# Altium schematic sheet Power.SchDoc
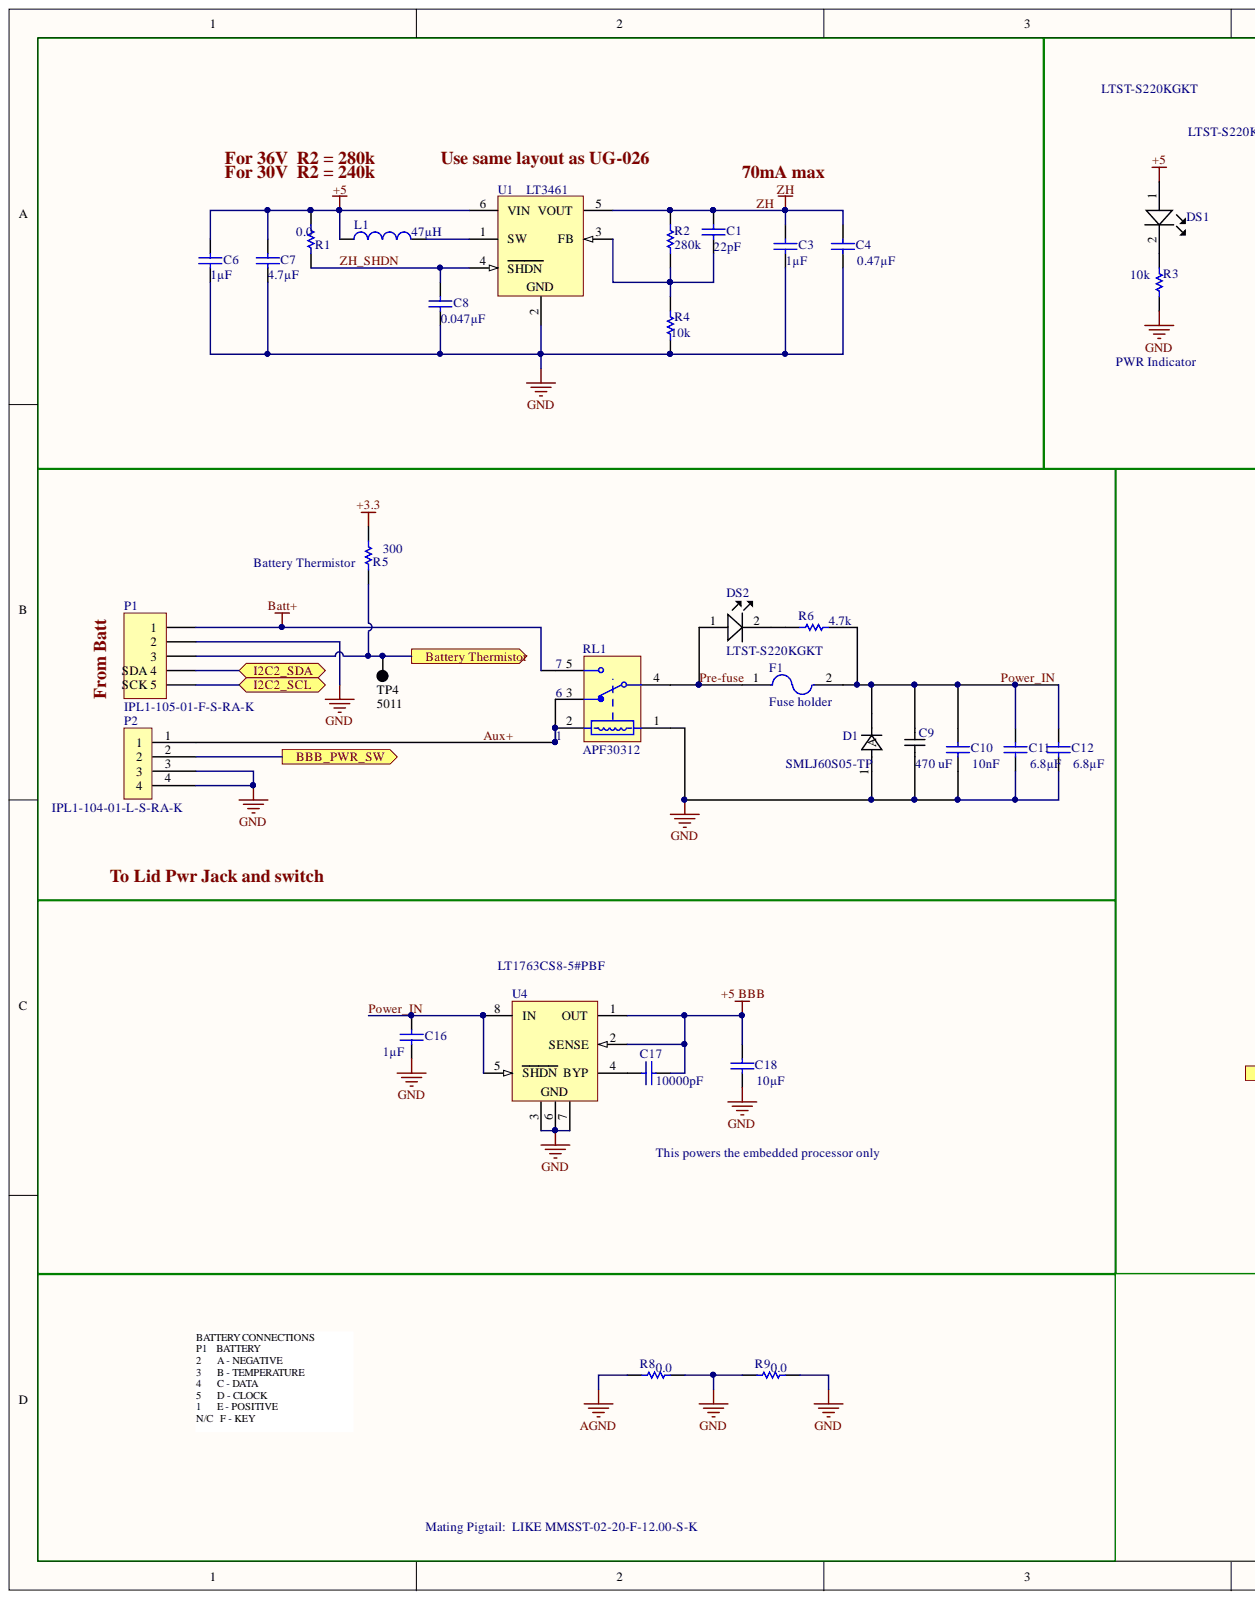
[diagram p0: Power.SchDoc, left]
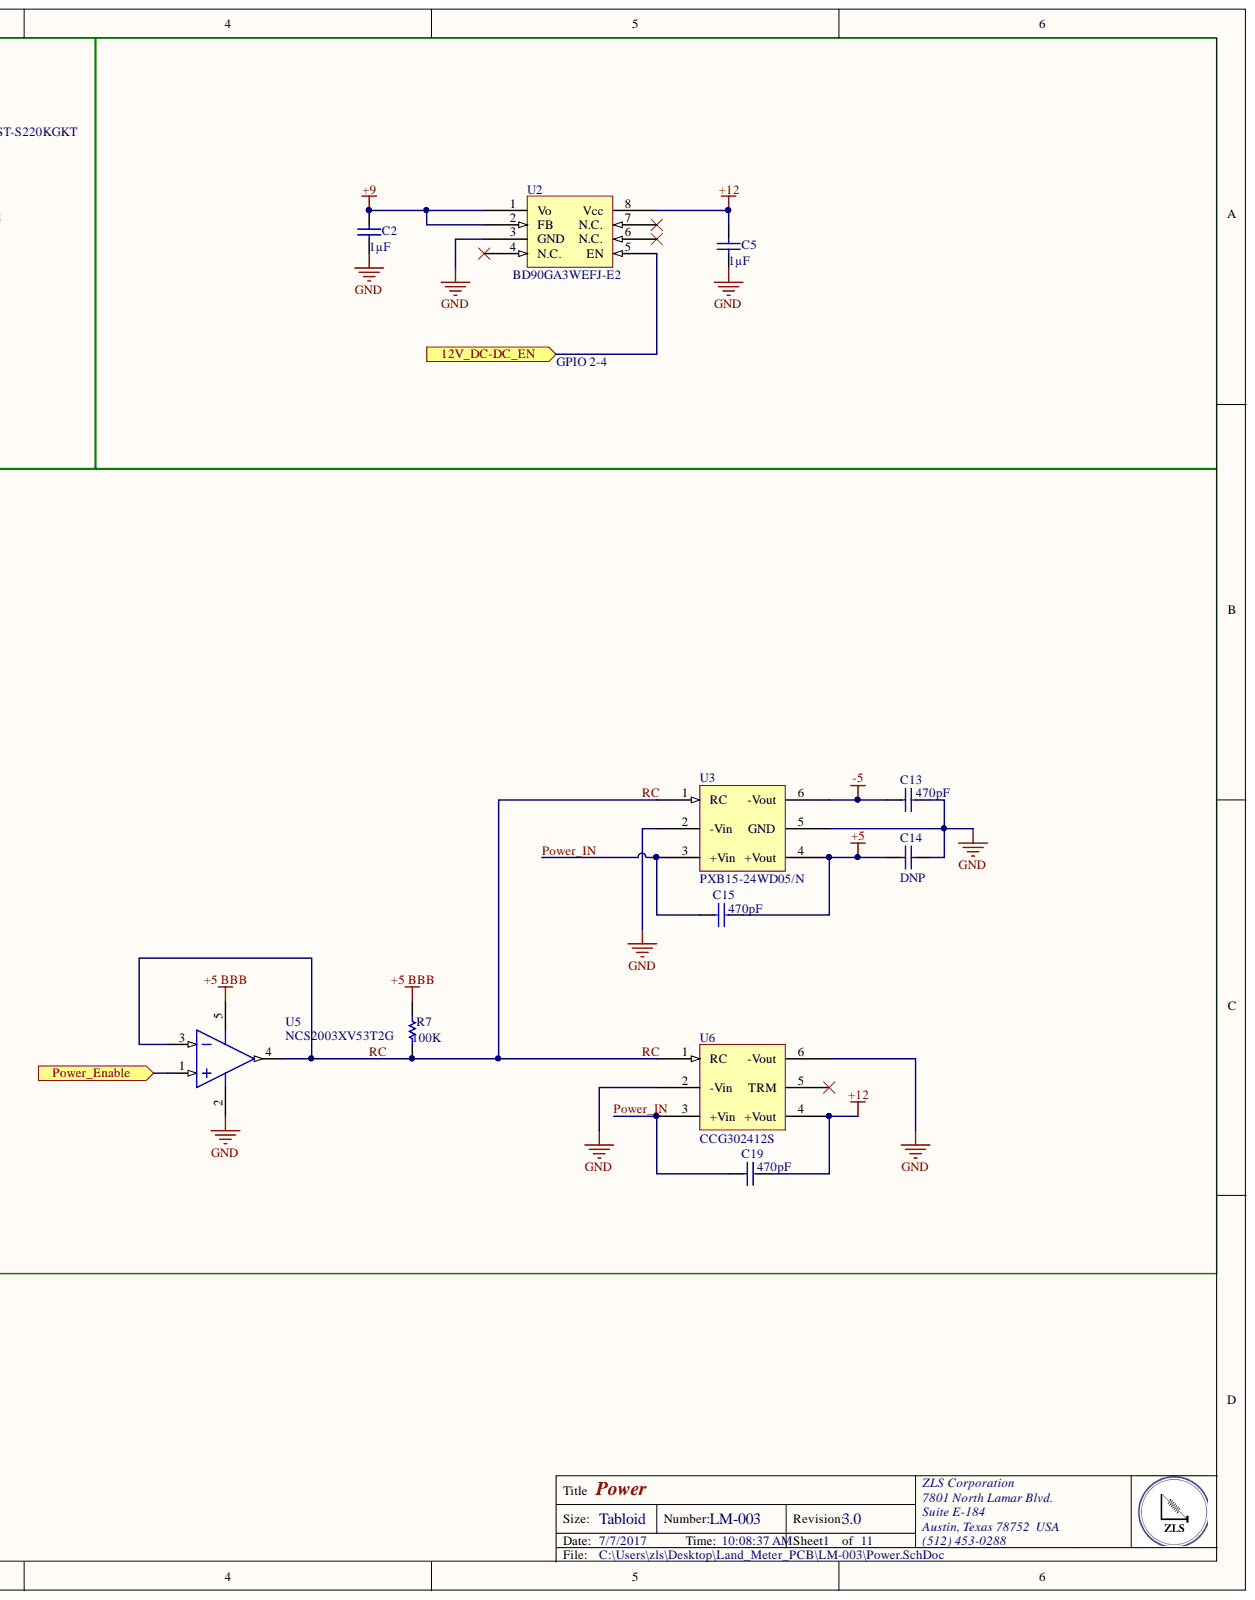
[diagram p1: Power.SchDoc, right]

## Parts
C1  Capacitance: 22pF  [CAPC0603(1608)100_N]  (hidden comment: CAP CER 22PF 50V X7R 0603)
C2  Capacitance: 1µF  [CAPC1206(3216)130_N]  (hidden comment: CAP CER 1UF 50V X7R 1210)
C3  Capacitance: 1µF  [CAPC1210(3225)100_N]  (hidden comment: CAP CER 1UF 50V X7R 1210)
C4  Capacitance: 0.47µF  [CAPC1206(3216)190_N]  (hidden comment: CAP CER 0.47UF 50V X7R 1206)
C5  Capacitance: 1µF  [CAPC1206(3216)130_N]  (hidden comment: CAP CER 1UF 50V X7R 1210)
C6  Capacitance: 1µF  [CAPC0805(2012)145_N]  (hidden comment: CAP CER 1UF 16V X7R 0805)
C7  Capacitance: 4.7µF  [CAPC1206(3216)130_N]  (hidden comment: CAP CER 4.7UF 16V X7R 1206)
C8  Capacitance: 0.047µF  [CAPC0805(2012)145_N]  (hidden comment: CAP CER 0.047UF 16V X7R 0603)
C9  Aluminum Electrolytic Capacitors - Leaded 16V 470uF 20%  [RAD-0.1]  (value not drawn)
C10  Capacitance: 10nF  [CAPC0805(2012)145_N]  (hidden comment: CAP CER 10000PF 50V X7R 0805)
C11  Capacitance: 6.8µF  [CAPC1210(3225)100_L]  (hidden comment: CAP CER 6.8UF 50V X5R 1206)
C12  Capacitance: 6.8µF  [CAPC1210(3225)100_L]  (hidden comment: CAP CER 6.8UF 50V X5R 1206)
C13  Capacitance: 470pF  [CAPC1812(4532)230_M]  (hidden comment: CAP CER 470PF 3KV X7R 1812)
C14  DNP  [CAPC1812(4532)230_M]
C15  Capacitance: 470pF  [CAPC1812(4532)230_M]  (hidden comment: CAP CER 470PF 3KV X7R 1812)
C16  Capacitance: 1µF  [CAPC1210(3225)100_L]  (hidden comment: CAP CER 1UF 50V X7R 1210)
C17  Capacitance: 10000pF  [CAPC0805(2012)145_N]  (hidden comment: CAP CER 10000PF 25V X7R 0805)
C18  Capacitance: 10µF  [CAPC0805(2012)145_N]  (hidden comment: CAP CER 10UF 10V X7R 0805)
C19  Capacitance: 470pF  [CAPC1812(4532)230_M]  (hidden comment: CAP CER 470PF 3KV X7R 1812)
D1  Manufacturer Part Number: SMLJ60S05-TP  [DO-214AB]  (hidden comment: DIODE GEN PURP 50V 6A DO214AB)
DS1  Lite-On LTST-S220KGKT Green LED, 571 nm 2012 (0805) Side View, Rectangle Lens SMD package  [0805C_L]  (value not drawn)
DS2  Manufacturer Part Number: LTST-S220KGKT  [0805C_L]  (hidden comment: Lite-On LTST-S220KGKT Green LED, 571 nm 2012 (0805) Side View, Rectangle Lens SMD package)
F1  Fuse holder  [01530007Z]
L1  Inductance: 47µH  [0402-A]  (hidden comment: FIXED IND 47UH 170MA 1.3 OHM SMD)
P1  IPL1-105-01-F-S-RA-K  [IPL1-105-1]
P2  IPL1-104-01-L-S-RA-K  [IPL1-104-01]
R1  Resistance (Ohms): 0.0  [RESC0805(2012)_M]  (hidden comment: RES SMD 0.0 OHM JUMPER 1/8W 0805)
R2  Resistance (Ohms): 280k  [RESC0805(2012)_M]  (hidden comment: RES SMD 280K OHM 1% 1/8W 0805)
R3  Resistance (Ohms): 10k  [RESC0805(2012)_M]  (hidden comment: RES SMD 10K OHM 1% 1/8W 0805)
R4  Resistance (Ohms): 10k  [RESC0805(2012)_M]  (hidden comment: RES SMD 10K OHM 1% 1/8W 0805)
R5  300K 5% 0805(2012)  [RESC0805(2012)_M]  (value not drawn)
R6  Resistance (Ohms): 4.7k  [RESC0805(2012)_M]  (hidden comment: RES SMD 4.7K OHM 1% 1/8W 0805)
R7  Resistance: 100K  [RESC0805(2012)_M]  (hidden comment: RES SMD 100K OHM 1% 1/8W 0805)
R8  Resistance (Ohms): 0.0  [RESC0805(2012)_M]  (hidden comment: RES SMD 0.0 OHM JUMPER 1/8W 0805)
R9  Resistance (Ohms): 0.0  [RESC0805(2012)_M]  (hidden comment: RES SMD 0.0 OHM JUMPER 1/8W 0805)
RL1  APF30312  [PNSC-PF-5-2800x500x1500_V]
TP4  5011  [KSTN-5011_V]
U1  LT3461  [LT-S6-6-TSOT-23_N]
U2  BD90GA3WEFJ-E2  [TSOP127P600X100-8N]
U3  PXB15-24WD05/N  [PXB15-24WD05/N]
U4  LT1763CS8-5#PBF  [LT-S8-8_M]
U5  NCS2003XV53T2G  [ONSC-SOT-553-5-463B_V]
U6  CCG302412S  [CCG30]

## Ports
12V_DC-DC_EN, Battery Thermistor, BBB_PWR_SW, I2C2_SCL, I2C2_SDA, Power_Enable

## Nets
+3.3: R5.2
+5: C6.2 C7.2 C14.1 C15.2 DS1.1 L1.1 R1.1 U1.6(VIN) U3.4(+Vout)
+5 BBB: C17.1 C18.2 R7.1 U4.1(OUT) U4.2(SENSE) U5.5
+9: C2.2 U2.1(Vo) U2.2(FB)
+12: C5.2 C19.2 U2.8(Vcc) U6.4(+Vout)
-5: C13.1 U3.6(-Vout)
AGND: R8.2
Batt+: P1.1 RL1.5
GND: C2.1 C3.1 C4.1 C5.1 C6.1 C7.1 C8.1 C9.2 C10.1 C11.1 C12.1 C13.2 C14.2 C16.1 C18.1 D1.1 P1.2 P2.3 P2.4 R3.1 R4.2 R8.1 R9.1 R9.2 RL1.1 U1.2 U2.3(GND) U3.2(-Vin) U3.5(GND) U4.3 U4.6 U4.7 U5.2 U6.2(-Vin) U6.6(-Vout)
12V_DC-DC_EN: U2.5(EN) ; port 12V_DC-DC_EN
Aux+: P2.1 RL1.2 RL1.3
Battery Thermistor: P1.3 R5.1 TP4.1 ; port Battery Thermistor
BBB_PWR_SW: P2.2 ; port BBB_PWR_SW
I2C2_SCL: P1.5(SCK_5) ; port I2C2_SCL
I2C2_SDA: P1.4(SDA_4) ; port I2C2_SDA
Power_Enable: U5.1 ; port Power_Enable
Power_IN: C9.1 C10.2 C11.2 C12.2 C15.1 C16.2 C19.1 D1.2 F1.2 R6.1 U3.3(+Vin) U4.5(SHDN) U4.8(IN) U6.3(+Vin)
Pre-fuse: DS2.1 F1.1 RL1.4
RC: R7.2 U3.1(RC) U5.3 U5.4 U6.1(RC)
ZH: C1.2 C3.2 C4.2 R2.1 U1.5(VOUT)
ZH_SHDN: C8.2 R1.2 U1.4(SHDN)
NetC1_1: C1.1 R2.2 R4.1 U1.3(FB)
NetC17_2: C17.2 U4.4(BYP)
NetDS1_2: DS1.2 R3.2
NetDS2_2: DS2.2 R6.2
NetL1_2: L1.2 U1.1(SW)

Not connected: U2 4(N.C.) 6(N.C.) 7(N.C.); U6 5(TRM)

## Library data of the parts
C1 = CMP-1035-00578-1: Manufacturer: AVX Corporation; Manufacturer Part Number: 06035C220JAT2A; Tolerance: ±5%; Applications: General Purpose; Category: Capacitors; Component Kind: Standard; ComponentLink1Description: http://datasheets.avx.com/X7RDielectric.pdf; ComponentLink1URL: http://datasheets.avx.com/X7RDielectric.pdf; Description: CAP CER 22PF 50V X7R 0603; Features: -; Height - Seated (Max): -; Lead Spacing: -; Lead Style: -; Library Name: Altium Content Vault; Mounting Type: Surface Mount, MLCC; Operating Temperature: -55°C ~ 125°C; Package / Case: 0603 (1608 Metric); Packaging: Digi-Reel®; Part Status: Active; Pricing 1: 1=0.2, 10=0.136, 100=0.0688, 1000=0.04166 (USD); Ratings: -; RoHS: RoHS Compliant; Size / Dimension: 0.063" L x 0.032" W (1.60mm x 0.81mm); Stock 1: 72942; Supplier 1: Digi-Key; Supplier Part Number 1: 478-6204-6-ND; Temperature Coefficient: X7R; Thickness (Max): 0.035" (0.90mm); Voltage - Rated: 50V
C2 C5 = CMP-1037-04913-1: Manufacturer: Murata Electronics North America; Manufacturer Part Number: GRM32RR71H105KA01L; Tolerance: ±10%; Applications: General Purpose; Category: Capacitors; Component Kind: Standard; ComponentLink1Description: http://search.murata.co.jp/Ceramy/image/img/A01X/G101/ENG/GRM32RR71H105KA01-01.pdf; ComponentLink1URL: http://search.murata.co.jp/Ceramy/image/img/A01X/G101/ENG/GRM32RR71H105KA01-01.pdf; Description: CAP CER 1UF 50V X7R 1210; Features: -; Height - Seated (Max): -; Lead Spacing: -; Lead Style: -; Library Name: Altium Content Vault; Mounting Type: Surface Mount, MLCC; Operating Temperature: -55°C ~ 125°C; Package / Case: 1210 (3225 Metric); Packaging: Digi-Reel®; Part Status: Active; Pricing 1: 1=0.35, 10=0.242, 100=0.1449, 500=0.10868 (USD); Ratings: -; RoHS: RoHS Compliant; Size / Dimension: 0.126" L x 0.098" W (3.20mm x 2.50mm); Stock 1: 66890; Supplier 1: Digi-Key; Supplier Part Number 1: 490-1863-6-ND; Temperature Coefficient: X7R; Thickness (Max): 0.079" (2.00mm); Voltage - Rated: 50V
C3 = CMP-1038-01123-1: Manufacturer: Taiyo Yuden; Manufacturer Part Number: UMK325B7105KH-T; Tolerance: ±10%; Applications: General Purpose; Category: Capacitors; Component Kind: Standard; ComponentLink1Description: http://www.yuden.co.jp/productdata/catalog/en/mlcc_all_e.pdf; ComponentLink1URL: http://www.yuden.co.jp/productdata/catalog/en/mlcc_all_e.pdf; Description: CAP CER 1UF 50V X7R 1210; Features: -; Height - Seated (Max): -; Lead Spacing: -; Lead Style: -; Library Name: Altium Content Vault; Mounting Type: Surface Mount, MLCC; Operating Temperature: -55°C ~ 125°C; Package / Case: 1210 (3225 Metric); Packaging: Cut Tape (CT); Part Status: Active; Pricing 1: 1=0.36, 10=0.247, 100=0.1398, 500=0.0987, 1000=0.08637 (USD); Ratings: -; RoHS: RoHS Compliant; Size / Dimension: 0.126" L x 0.098" W (3.20mm x 2.50mm); Stock 1: 23864; Supplier 1: Digi-Key; Supplier Part Number 1: 587-1366-1-ND; Temperature Coefficient: X7R; Thickness (Max): 0.063" (1.60mm); Voltage - Rated: 50V
C4 = CMP-1037-04570-1: Manufacturer: Taiyo Yuden; Manufacturer Part Number: UMK316B7474KL-T; Tolerance: ±10%; Applications: General Purpose; Category: Capacitors; Component Kind: Standard; ComponentLink1Description: http://www.yuden.co.jp/productdata/catalog/en/mlcc_all_e.pdf; ComponentLink1URL: http://www.yuden.co.jp/productdata/catalog/en/mlcc_all_e.pdf; Description: CAP CER 0.47UF 50V X7R 1206; Features: -; Height - Seated (Max): -; Lead Spacing: -; Lead Style: -; Library Name: Altium Content Vault; Mounting Type: Surface Mount, MLCC; Operating Temperature: -55°C ~ 125°C; Package / Case: 1206 (3216 Metric); Packaging: Cut Tape (CT); Part Status: Active; Pricing 1: 1=0.3, 10=0.203, 100=0.115, 500=0.08114, 1000=0.071 (USD); Ratings: -; RoHS: RoHS Compliant; Size / Dimension: 0.126" L x 0.063" W (3.20mm x 1.60mm); Stock 1: 8658; Supplier 1: Digi-Key; Supplier Part Number 1: 587-1325-1-ND; Temperature Coefficient: X7R; Thickness (Max): 0.071" (1.80mm); Voltage - Rated: 50V
C6 = CMP-1036-04795-1: Manufacturer: Taiyo Yuden; Manufacturer Part Number: EMK212B7105KG-T; Tolerance: ±10%; Applications: General Purpose; Category: Capacitors; Component Kind: Standard; ComponentLink1Description: http://ds.yuden.co.jp/TYCOMPAS/ut/detail.do?productNo=EMK212B7105KG-T&fileName=EMK212B7105KG-T_SS&mode=specSheetDownload; ComponentLink1URL: http://ds.yuden.co.jp/TYCOMPAS/ut/detail.do?productNo=EMK212B7105KG-T&fileName=EMK212B7105KG-T_SS&mode=specSheetDownload; Description: CAP CER 1UF 16V X7R 0805; Features: -; Height - Seated (Max): -; Lead Spacing: -; Lead Style: -; Library Name: Altium Content Vault; Mounting Type: Surface Mount, MLCC; Operating Temperature: -55°C ~ 125°C; Package / Case: 0805 (2012 Metric); Packaging: Cut Tape (CT); Part Status: Active; Pricing 1: 1=0.13, 10=0.089, 100=0.042, 1000=0.02439 (USD); Ratings: -; RoHS: RoHS Compliant; Size / Dimension: 0.079" L x 0.049" W (2.00mm x 1.25mm); Stock 1: 41495; Supplier 1: Digi-Key; Supplier Part Number 1: 587-1283-1-ND; Temperature Coefficient: X7R; Thickness (Max): 0.053" (1.35mm); Voltage - Rated: 16V
C7 = CMP-1037-04913-1: Manufacturer: Taiyo Yuden; Manufacturer Part Number: EMK316B7475KL-T; Tolerance: ±10%; Applications: General Purpose; Category: Capacitors; Component Kind: Standard; ComponentLink1Description: http://ds.yuden.co.jp/TYCOMPAS/ut/detail.do?productNo=EMK316B7475KL-T&fileName=EMK316B7475KL-T_SS&mode=specSheetDownload; ComponentLink1URL: http://ds.yuden.co.jp/TYCOMPAS/ut/detail.do?productNo=EMK316B7475KL-T&fileName=EMK316B7475KL-T_SS&mode=specSheetDownload; Description: CAP CER 4.7UF 16V X7R 1206; Features: -; Height - Seated (Max): -; Lead Spacing: -; Lead Style: -; Library Name: Altium Content Vault; Mounting Type: Surface Mount, MLCC; Operating Temperature: -55°C ~ 125°C; Package / Case: 1206 (3216 Metric); Packaging: Digi-Reel®; Part Status: Active; Pricing 1: 1=0.25, 10=0.17, 100=0.0965, 1000=0.0596 (USD); Ratings: -; RoHS: RoHS Compliant; Size / Dimension: 0.126" L x 0.063" W (3.20mm x 1.60mm); Stock 1: 59490; Supplier 1: Digi-Key; Supplier Part Number 1: 587-1331-6-ND; Temperature Coefficient: X7R; Thickness (Max): 0.071" (1.80mm); Voltage - Rated: 16V
C8 = CMP-1036-04795-1: Manufacturer: Murata Electronics North America; Manufacturer Part Number: GRM188R71C473KA01D; Tolerance: ±10%; Applications: General Purpose; Category: Capacitors; Component Kind: Standard; ComponentLink1Description: http://www.murata.com/~/media/webrenewal/support/library/catalog/products/capacitor/mlcc/c02e.ashx; ComponentLink1URL: http://www.murata.com/~/media/webrenewal/support/library/catalog/products/capacitor/mlcc/c02e.ashx; Description: CAP CER 0.047UF 16V X7R 0603; Features: -; Height - Seated (Max): -; Lead Spacing: -; Lead Style: -; Library Name: Altium Content Vault; Mounting Type: Surface Mount, MLCC; Operating Temperature: -55°C ~ 125°C; Package / Case: 0603 (1608 Metric); Packaging: Digi-Reel®; Part Status: Active; Pricing 1: 1=0.1, 10=0.046, 100=0.0206, 500=0.0147, 1000=0.01155 (USD); Ratings: -; RoHS: RoHS Compliant; Size / Dimension: 0.063" L x 0.031" W (1.60mm x 0.80mm); Stock 1: 26; Supplier 1: Digi-Key; Supplier Part Number 1: 490-1529-6-ND; Temperature Coefficient: X7R; Thickness (Max): 0.035" (0.90mm); Voltage - Rated: 16V
C9 = CAP B: Manufacturer Part Number 1: TEA471M1CBK-0816P; Brand: Lelon; Case Diameter: 8 mm; Case Length: 16 mm; Dissipation Factor DF: 0.17; Load Life: 2000 h; Product Status: Factory Special Order; Ripple Current: 460 mA; Capacitance: 470 uF; Category 1: Aluminum Electrolytic Capacitors - Leaded; Component Kind: Standard; ComponentLink1Description: http://www.mouser.com/catalog/specsheets/ea.pdf; ComponentLink1URL: http://www.mouser.com/catalog/specsheets/ea.pdf; Description: Aluminum Electrolytic Capacitors - Leaded 16V 470uF 20%; Description 1: Aluminum Electrolytic Capacitors - Leaded 16V 470uF 20%; Dimensions: 8 mm Dia. x 16 mm L; Manufacturer 1: Lelon; Maximum Operating Temperature: + 85 C; Minimum Order Quantity: 2000; Product: General Purpose Electrolytic Capacitors; Product Category: Axial Electrolytic Capacitors; Reeling: False; RoHS 1: RoHS Compliant; RoHS - Mouser: Y; Series: TEA; Standard Pack Qty: 500; Stock 1: 0; Supplier 1: Mouser; Supplier Part Number 1: 140-TEA471M1CBK0816P; Termination Style: Axial; Tolerance: 20 %; Voltage Rating DC: 16 V
C10 = CMP-1036-04795-1: Manufacturer: Kemet; Manufacturer Part Number: C0805F103K5RACTU; Dielectric Material: Ceramic; Tolerance: 10%; Case/Package: 0805; Case Code (Imperial): 0805; Case Code (Metric): 2012; Component Kind: Standard; ComponentLink1Description: https://datasheet.ciiva.com/146/docfinderpartnumber-c0805f103k5ractu-146278.pdf; ComponentLink1URL: https://datasheet.ciiva.com/146/docfinderpartnumber-c0805f103k5ractu-146278.pdf; ComponentLink2Description: https://datasheet.ciiva.com/7842/48829-7842407.pdf; ComponentLink2URL: https://datasheet.ciiva.com/7842/48829-7842407.pdf; ComponentLink3Description: https://datasheet.ciiva.com/7842/48829-7842408.pdf; ComponentLink3URL: https://datasheet.ciiva.com/7842/48829-7842408.pdf; Depth: 1.25mm; Description: CAP CER 10000PF 50V X7R 0805; Dielectric: X7R; Height: 0.9mm; Lead Pitch: 0.75mm; Length: 2mm; Library Name: Altium Content Vault; Max Operating Temperature: 125°C; Min Operating Temperature: -55°C; Mount: Surface Mount; Number of Pins: 2; Package Quantity: 4000; Packaging: Cut Tape; Pricing 1: 1=0.29, 10=0.202, 100=0.1146, 500=0.0809, 1000=0.0708 (USD); REACH SVHC: No SVHC; Stock 1: 29640; Supplier 1: Digi-Key; Supplier Part Number 1: 399-5107-6-ND; Termination: SMD/SMT; Thickness: 0.039inch; Voltage: 50V; Voltage Rating: 50V; Voltage Rating (DC): 50V; Width: 1.25mm
C11 C12 = CMP-1038-00632-1: Manufacturer: TDK Corporation; Manufacturer Part Number: C3216X5R1H685K160AB; Tolerance: ±10%; Applications: General Purpose; Category: Capacitors; Component Kind: Standard; ComponentLink1Description: https://product.tdk.com/info/en/catalog/datasheets/mlcc_commercial_general_en.pdf; ComponentLink1URL: https://product.tdk.com/info/en/catalog/datasheets/mlcc_commercial_general_en.pdf; Description: CAP CER 6.8UF 50V X5R 1206; Failure Rate: -; Features: Low ESL; Height - Seated (Max): -; Lead Spacing: -; Lead Style: -; Library Name: Altium Content Vault; Mounting Type: Surface Mount, MLCC; Operating Temperature: -55°C ~ 85°C; Package / Case: 1206 (3216 Metric); Packaging: Digi-Reel®; Part Status: Active; Pricing 1: 1=1.03, 10=0.785, 100=0.5615, 500=0.4347, 1000=0.37433 (USD); Ratings: -; RoHS: RoHS Compliant; Size / Dimension: 0.126" L x 0.063" W (3.20mm x 1.60mm); Stock 1: 29817; Supplier 1: Digi-Key; Supplier Part Number 1: 445-5996-6-ND; Temperature Coefficient: X5R; Thickness (Max): 0.071" (1.80mm); Voltage - Rated: 50V
C13 C15 C19 = CMP-1040-00561-1: Manufacturer: Kemet; Manufacturer Part Number: C1812C471KHRACTU; Tolerance: 10%; Case/Package: 1812; Case Code (Imperial): 1812; Case Code (Metric): 4532; Component Kind: Standard; ComponentLink1Description: http://www.kemet.com/docfinder?Partnumber=C1812C471KHRACTU; ComponentLink1URL: http://www.kemet.com/docfinder?Partnumber=C1812C471KHRACTU; Description: CAP CER 470PF 3KV X7R 1812; Lead Free: Lead Free; Length: 0.177inch; Library Name: Altium Content Vault; Max Operating Temperature: 125°C; Min Operating Temperature: -55°C; Package Quantity: 1; Packaging: Tape and Reel; Pricing 1: 1=0.88, 10=0.666, 100=0.4761 (USD); Stock 1: 2705; Supplier 1: Digi-Key; Supplier Part Number 1: 399-7289-6-ND; Thickness: 0.071inch; Voltage Rating: 3kV; Voltage Rating (DC): 3kV; Width: 0.126inch
C14 = CMP-1040-00561-1: CAP CER 470PF 3KV X7R 1812; Manufacturer: Kemet; Manufacturer Part Number: C1812C471KHRACTU; Tolerance: 10%; Capacitance: 470pF; Case/Package: 1812; Case Code (Imperial): 1812; Case Code (Metric): 4532; Component Kind: Standard; ComponentLink1Description: http://www.kemet.com/docfinder?Partnumber=C1812C471KHRACTU; ComponentLink1URL: http://www.kemet.com/docfinder?Partnumber=C1812C471KHRACTU; Lead Free: Lead Free; Length: 0.177inch; Library Name: Altium Content Vault; Max Operating Temperature: 125°C; Min Operating Temperature: -55°C; Package Quantity: 1; Packaging: Tape and Reel; Pricing 1: 1=0.88, 10=0.666, 100=0.4761 (USD); Stock 1: 2705; Supplier 1: Digi-Key; Supplier Part Number 1: 399-7289-6-ND; Thickness: 0.071inch; Voltage Rating: 3kV; Voltage Rating (DC): 3kV; Width: 0.126inch
C16 = CMP-1038-00632-1: Manufacturer: Murata Electronics North America; Manufacturer Part Number: GRM32RR71H105KA01L; Tolerance: ±10%; Applications: General Purpose; Category: Capacitors; Component Kind: Standard; ComponentLink1Description: http://search.murata.co.jp/Ceramy/image/img/A01X/G101/ENG/GRM32RR71H105KA01-01.pdf; ComponentLink1URL: http://search.murata.co.jp/Ceramy/image/img/A01X/G101/ENG/GRM32RR71H105KA01-01.pdf; Description: CAP CER 1UF 50V X7R 1210; Features: -; Height - Seated (Max): -; Lead Spacing: -; Lead Style: -; Library Name: Altium Content Vault; Mounting Type: Surface Mount, MLCC; Operating Temperature: -55°C ~ 125°C; Package / Case: 1210 (3225 Metric); Packaging: Digi-Reel®; Part Status: Active; Pricing 1: 1=0.35, 10=0.242, 100=0.1449, 500=0.10868 (USD); Ratings: -; RoHS: RoHS Compliant; Size / Dimension: 0.126" L x 0.098" W (3.20mm x 2.50mm); Stock 1: 66890; Supplier 1: Digi-Key; Supplier Part Number 1: 490-1863-6-ND; Temperature Coefficient: X7R; Thickness (Max): 0.079" (2.00mm); Voltage - Rated: 50V
C17 = CMP-1036-04795-1: Manufacturer: Murata Electronics North America; Manufacturer Part Number: GRM216R71E103KA01D; Tolerance: ±10%; Applications: General Purpose; Category: Capacitors; Component Kind: Standard; ComponentLink1Description: http://www.murata.com/~/media/webrenewal/support/library/catalog/products/capacitor/mlcc/c02e.ashx; ComponentLink1URL: http://www.murata.com/~/media/webrenewal/support/library/catalog/products/capacitor/mlcc/c02e.ashx; Description: CAP CER 10000PF 25V X7R 0805; Features: -; Height - Seated (Max): -; Lead Spacing: -; Lead Style: -; Library Name: Altium Content Vault; Mounting Type: Surface Mount, MLCC; Operating Temperature: -55°C ~ 125°C; Package / Case: 0805 (2012 Metric); Packaging: Digi-Reel®; Part Status: Active; Pricing 1: 1=0.11, 10=0.077, 100=0.0343, 500=0.02452, 1000=0.01926 (USD); Ratings: -; RoHS: RoHS Compliant; Size / Dimension: 0.079" L x 0.049" W (2.00mm x 1.25mm); Stock 1: 13127; Supplier 1: Digi-Key; Supplier Part Number 1: 490-12748-6-ND; Temperature Coefficient: X7R; Thickness (Max): 0.028" (0.70mm); Voltage - Rated: 25V
C18 = CMP-1036-04795-1: Manufacturer: Murata Electronics North America; Manufacturer Part Number: GCM21BR71A106KE22L; Tolerance: ±10%; Applications: Automotive; Category: Capacitors; Component Kind: Standard; ComponentLink1Description: http://search.murata.co.jp/Ceramy/image/img/A01X/G101/ENG/GCM21BR71A106KE22-01.pdf; ComponentLink1URL: http://search.murata.co.jp/Ceramy/image/img/A01X/G101/ENG/GCM21BR71A106KE22-01.pdf; Description: CAP CER 10UF 10V X7R 0805; Features: -; Height - Seated (Max): -; Lead Spacing: -; Lead Style: -; Library Name: Altium Content Vault; Mounting Type: Surface Mount, MLCC; Operating Temperature: -55°C ~ 125°C; Package / Case: 0805 (2012 Metric); Packaging: Digi-Reel®; Part Status: Active; Pricing 1: 1=0.49, 10=0.347, 100=0.2282, 500=0.16906, 1000=0.14369 (USD); Ratings: AEC-Q200; RoHS: RoHS Compliant; Size / Dimension: 0.079" L x 0.049" W (2.00mm x 1.25mm); Stock 1: 136726; Supplier 1: Digi-Key; Supplier Part Number 1: 490-10674-6-ND; Temperature Coefficient: X7R; Thickness (Max): 0.055" (1.40mm); Voltage - Rated: 10V
D1 = DIODE A/K A: Manufacturer: Micro Commercial Co; Manufacturer 1: ON Semiconductor; Category 1: Discrete Semiconductor Products; Current - Reverse Leakage @ Vr: 25µA @ 50V; Description 1: DIODE GP 50V 6A MICRODE BUTTON; Diode Type: Standard; Speed: Standard Recovery >500ns, > 200mA (Io); Voltage - Forward (Vf) (Max) @ If: 1V @ 6A; Capacitance @ Vr, F: -; Category: Discrete Semiconductor Products; Component Kind: Standard; ComponentLink1Description: http://www.onsemi.com/pub_link/Collateral/MR750-D.PDF; ComponentLink1URL: http://www.onsemi.com/pub_link/Collateral/MR750-D.PDF; ComponentLink2Description: http://www.mccsemi.com/up_pdf/SMLJ60S05~SMLJ60S10(DO-214AB).pdf; ComponentLink2URL: http://www.mccsemi.com/up_pdf/SMLJ60S05~SMLJ60S10(DO-214AB).pdf; Current - Average Rectified (Io): 6A; Description: DIODE GEN PURP 50V 6A DO214AB; Mounting Type: Surface Mount; Operating Temperature - Junction: -55°C ~ 150°C; Package / Case: DO-214AB, SMC; Packaging: Cut Tape (CT); Part Status: Active; Pricing 1: 1=1.06, 10=0.939, 25=0.848, 100=0.7419, 250=0.65108, 500=0.57536, 1000=0.45423 (USD); RoHS: RoHS Compliant; Stock 1: 6086; Supplier 1: Digi-Key; Supplier Device Package: DO-214AB, (SMC); Supplier Part Number 1: SMLJ60S05-TPMSCT-ND; Voltage - DC Reverse (Vr) (Max): 50V
DS1 DS2 = LED A/K: Manufacturer: Vishay / Lite-On; Dominant Wavelength: 568nm; Forward Current: 20mA; Forward Voltage: 2V; Lens Colour: Colorless; Lens Style: Diffused,Colorless; Lens Transparency: Clear; Luminous Intensity: 35mcd; Number of Elements: 1; Power Dissipation: 75W; Power Rating: 75mW; Viewing Angle: 130°; Wavelength: 574nm; Case/Package: 0805; Case Code (Imperial): 0805; Case Code (Metric): 2012; Colour: Green; Component Kind: Standard; ComponentLink1Description: https://datasheet.ciiva.com/11775/0900766b80dc0b70-11775441.pdf; ComponentLink1URL: https://datasheet.ciiva.com/11775/0900766b80dc0b70-11775441.pdf; ComponentLink2Description: https://datasheet.ciiva.com/2110/s-110-ltst-s220kgkt-2110069.pdf; ComponentLink2URL: https://datasheet.ciiva.com/2110/s-110-ltst-s220kgkt-2110069.pdf; ComponentLink3Description: https://datasheet.ciiva.com/2110/s-110-ltst-s220kgkt-2110070.pdf; ComponentLink3URL: https://datasheet.ciiva.com/2110/s-110-ltst-s220kgkt-2110070.pdf; ComponentLink4Description: https://datasheet.ciiva.com/12400/100-ltst-s220kgkt-59602-12400886.pdf; ComponentLink4URL: https://datasheet.ciiva.com/12400/100-ltst-s220kgkt-59602-12400886.pdf; ComponentLink5Description: https://datasheet.ciiva.com/2110/s-110-ltst-s220kgkt-2110071.pdf; ComponentLink5URL: https://datasheet.ciiva.com/2110/s-110-ltst-s220kgkt-2110071.pdf; ComponentLink6Description: https://datasheet.ciiva.com/16379/ltst-s220kgkt-16379082.pdf; ComponentLink6URL: https://datasheet.ciiva.com/16379/ltst-s220kgkt-16379082.pdf; Depth: 0.6mm; Description: Lite-On LTST-S220KGKT Green LED, 571 nm 2012 (0805) Side View, Rectangle Lens SMD package; Height: 0.6mm; Illumination Colour: Green; Lead Free: Lead Free; Length: 2.1mm; Max Operating Temperature: 85°C; Min Operating Temperature: -55°C; Mount: Surface Mount; Number of LEDs: 1; Number of Pins: 2; Package Quantity: 4000; Packaging: Tape and Reel; Pricing 1: 1=0.29, 10=0.212, 25=0.1564, 100=0.1117, 250=0.0759, 500=0.067, 1000=0.0525 (USD); Radiation Hardening: No; Stock 1: 74800; Supplier 1: Digi-Key; Supplier Part Number 1: 160-1468-1-ND; Test Current: 20mA; Width: 1mm
  DS1: Manufacturer Part Number: LTST-S220KGKT
  DS2: ComponentLink7Description: http://www.farnell.com/cad/2124774.pdf; ComponentLink7URL: http://www.farnell.com/cad/2124774.pdf; ComponentLink8Description: http://www.farnell.com/datasheets/693084.pdf; ComponentLink8URL: http://www.farnell.com/datasheets/693084.pdf; Pricing 2: 1=0.325, 10=0.317, 100=0.23, 200=0.228, 400=0.182, 900=0.147, 3000=0.114, 9000=0.107 (GBP); RoHS: YES; Stock 2: 2271; Supplier 2: Farnell; Supplier Part Number 2: 2062310
F1 = Fuse 2: FUSE HOLDER BLADE 32V 15A PCB; Manufacturer 1: Littelfuse Inc.; Category 1: Circuit Protection; For Use With/Related Products: MINI ATC, MINI ATO; Fuse Size: 0.43" L x 0.15" W x 0.64" H (10.92mm x 3.81mm x 16.25mm); Number of Circuits: 1; Component Kind: Standard; ComponentLink1Description: http://www.littelfuse.com/~/media/commercial-vehicle/datasheets/automotive-fuse-holders/mini/littelfuse_fuse-holders-mini-153-pc-datasheet.pdf; ComponentLink1URL: http://www.littelfuse.com/~/media/commercial-vehicle/datasheets/automotive-fuse-holders/mini/littelfuse_fuse-holders-mini-153-pc-datasheet.pdf; Contact Finish: Tin; Contact Material: Copper Alloy; Current Rating: 15A; Description 1: FUSE HOLDER BLADE 32V 15A PCB; Fuseholder Type: Holder; Fuse Type: Blade; Library Name: Miscellaneous Devices.IntLib; Manufacturer Part Number 1: 01530007Z; Mounting Type: PCB; Orientation: Horizontal; Part Status: Active; Pricing 1: 1=3.45, 5=3.336, 10=3.22, 50=2.53, 100=2.07, 250=2.01252, 500=1.725, 1000=1.61, 5000=1.4375 (USD); RoHS 1: RoHS Compliant; Simulation: FUSE; Stock 1: 4234; Supplier 1: Digi-Key; Supplier Part Number 1: F064-ND; Termination Style: PC Pin; Voltage: 32V
L1 = Inductor: Manufacturer 1: Murata Electronics North America; Manufacturer Part Number 1: LQH32CN470K23L; Category 1: Inductors, Coils, Chokes; DC Resistance (DCR): 1.3 Ohm; Frequency - Self Resonant: 15MHz; Frequency - Test: 1MHz; Material - Core: Ferrite; Shielding: Unshielded; Tolerance: ±10%; Type: Wirewound; Component Kind: Standard; ComponentLink1Description: http://search.murata.co.jp/Ceramy/image/img/PDF/ENG/L0075S0093LQH32C_23~33.pdf; ComponentLink1URL: http://search.murata.co.jp/Ceramy/image/img/PDF/ENG/L0075S0093LQH32C_23~33.pdf; Current - Saturation: -; Current Rating: 170mA; Description: FIXED IND 47UH 170MA 1.3 OHM SMD; Description 1: FIXED IND 47UH 170MA 1.3 OHM SMD; Height - Seated (Max): 0.067" (1.70mm); Library Name: Miscellaneous Devices.IntLib; Mounting Type: Surface Mount; Operating Temperature: -40°C ~ 85°C; Package / Case: 1210 (3225 Metric); Packaging: Cut Tape (CT); Pricing 1: 1=0.32, 10=0.291, 25=0.2584, 50=0.2262, 100=0.21, 250=0.1938, 500=0.18412, 750=0.16796, 1000=0.14858 (USD); Q @ Freq: -; RoHS 1: RoHS Compliant; Signal Integrity: Inductor; Simulation: INDUCTOR; Size / Dimension: 0.126" L x 0.098" W (3.20mm x 2.50mm); Stock 1: 39785; Supplier 1: Digi-Key; Supplier Device Package: 1210; Supplier Part Number 1: 490-2497-1-ND
P1 = Header 5H: Header, 5-Pin, Right Angle; Manufacturer 1: Samtec Inc.; Category 1: Connectors, Interconnects; Contact Length - Mating: 0.215" (5.46mm); Contact Length - Post: 0.085" (2.16mm); Description 1: .100 TERMINAL ASSEMBLY; Material Flammability Rating: UL94 V-0; Number of Positions: 5; Number of Positions Loaded: All; Pitch - Mating: 0.100" (2.54mm); Shrouding: Shrouded - 4 Wall; Style: Board to Cable/Wire; Voltage Rating: 675VAC, 954VDC; Applications: -; Component Kind: Standard; ComponentLink1Description: http://suddendocs.samtec.com/prints/ipl1-1xx-xx-xxx-s-xx-x-xx-mkt.pdf; ComponentLink1URL: http://suddendocs.samtec.com/prints/ipl1-1xx-xx-xxx-s-xx-x-xx-mkt.pdf; Connector Type: Header; Contact Finish - Mating: Gold; Contact Finish - Post: Tin; Contact Finish Thickness - Mating: 3µin (0.08µm); Contact Finish Thickness - Post: -; Contact Material: Phosphor Bronze; Contact Shape: Square; Contact Type: Male Pin; Current Rating: -; Fastening Type: Locking Ramp; Features: -; Ingress Protection: -; Insulation Color: Natural; Insulation Height: 0.240" (6.10mm); Insulation Material: Liquid Crystal Polymer (LCP); Library Name: Miscellaneous Connectors.IntLib; Manufacturer Part Number 1: IPL1-105-01-F-S-RA-K; Mated Stacking Heights: -; Mounting Type: Through Hole, Right Angle; Number of Rows: 1; Operating Temperature: -55°C ~ 125°C; Overall Contact Length: -; Packaging: Tube; Part Status: Active; Pricing 1: 1=2.46 (USD); RoHS 1: RoHS Compliant; Row Spacing - Mating: -; Signal Integrity: Connector; Stock 1: 0; Supplier 1: Digi-Key; Supplier Part Number 1: IPL1-105-01-F-S-RA-K-ND; Termination: Solder
P2 = 53047-0410: .100 TERMINAL ASSY; Manufacturer 1: Samtec Inc.; Category 1: Connectors, Interconnects; Connector Type: Header; Contact Length - Mating: 0.215" (5.46mm); Contact Length - Post: 0.085" (2.16mm); Material Flammability Rating: UL94 V-0; Number of Positions: 4; Number of Positions Loaded: All; Pitch - Mating: 0.100" (2.54mm); Shrouding: Shrouded - 4 Wall; Style: Board to Cable/Wire; Voltage Rating: 675VAC, 954VDC; Applications: -; Component Kind: Standard; ComponentLink1Description: http://suddendocs.samtec.com/prints/ipl1-1xx-xx-xxx-s-xx-x-xx-mkt.pdf; ComponentLink1URL: http://suddendocs.samtec.com/prints/ipl1-1xx-xx-xxx-s-xx-x-xx-mkt.pdf; Contact Finish - Mating: Gold; Contact Finish - Post: Tin; Contact Finish Thickness - Mating: 10µin (0.25µm); Contact Finish Thickness - Post: -; Contact Material: Phosphor Bronze; Contact Shape: Square; Contact Type: Male Pin; Current Rating: -; Description 1: .100 TERMINAL ASSY; Fastening Type: Locking Ramp; Features: -; Ingress Protection: -; Insulation Color: Natural; Insulation Height: 0.240" (6.10mm); Insulation Material: Liquid Crystal Polymer (LCP); Manufacturer Part Number 1: IPL1-104-01-L-S-RA-K; Mated Stacking Heights: -; Mounting Type: Through Hole, Right Angle; Number of Rows: 1; Operating Temperature: -55°C ~ 125°C; Overall Contact Length: -; Packaging: Tube; Part Status: Active; Pricing 1: 1=2.53, 10=2.424, 25=2.222, 50=2.121, 100=2.02, 250=1.76752, 500=1.717, 1000=1.4645, 2500=1.3635 (USD); RoHS 1: RoHS Compliant; Row Spacing - Mating: -; Stock 1: 100; Supplier 1: Digi-Key; Supplier Part Number 1: SAM9597-ND; Termination: Solder; Library Name: ZLS_LIB.SchLib
R1 R8 R9 = CMP-1013-00017-1: Manufacturer: Vishay Dale; Manufacturer Part Number: CRCW08050000Z0EA; Power (Watts): 0.125W, 1/8W; Category: Resistors; Component Kind: Standard; ComponentLink1Description: http://www.vishay.com/docs/20035/dcrcwe3.pdf; ComponentLink1URL: http://www.vishay.com/docs/20035/dcrcwe3.pdf; Composition: Thick Film; Description: RES SMD 0.0 OHM JUMPER 1/8W 0805; Failure Rate: -; Features: Automotive AEC-Q200; Height - Seated (Max): 0.020" (0.50mm); Library Name: Altium Content Vault; Operating Temperature: -55°C ~ 155°C; Package / Case: 0805 (2012 Metric); Part Status: Active; Pricing 1: 1=0.1, 10=0.026, 25=0.0184, 50=0.014, 100=0.0104, 250=0.00792, 500=0.00634, 1000=0.00466 (USD); RoHS: RoHS Compliant; Size / Dimension: 0.079" L x 0.049" W (2.00mm x 1.25mm); Supplier 1: Digi-Key; Supplier Device Package: 0805; Temperature Coefficient: -; Tolerance: Jumper
  R1: Packaging: Cut Tape (CT); Stock 1: 117072; Supplier Part Number 1: 541-0.0ACT-ND
  R8 R9: Packaging: Digi-Reel®; Stock 1: 406953; Supplier Part Number 1: 541-0.0ADKR-ND
R2 = CMP-1013-00017-1: Manufacturer: Vishay Dale; Manufacturer Part Number: CRCW0805280KFKEA; Power (Watts): 0.125W, 1/8W; Temperature Coefficient: ±100ppm/°C; Category: Resistors; Component Kind: Standard; ComponentLink1Description: http://www.vishay.com/docs/20035/dcrcwe3.pdf; ComponentLink1URL: http://www.vishay.com/docs/20035/dcrcwe3.pdf; Composition: Thick Film; Description: RES SMD 280K OHM 1% 1/8W 0805; Failure Rate: -; Features: Automotive AEC-Q200; Height - Seated (Max): 0.020" (0.50mm); Library Name: Altium Content Vault; Operating Temperature: -55°C ~ 155°C; Package / Case: 0805 (2012 Metric); Packaging: Tape & Reel (TR); Part Status: Active; Pricing 1: 5000=0.00546, 10000=0.00474, 25000=0.00417, 50000=0.00382, 125000=0.00375 (USD); RoHS: RoHS Compliant; Size / Dimension: 0.079" L x 0.049" W (2.00mm x 1.25mm); Stock 1: 10000; Supplier 1: Digi-Key; Supplier Device Package: 0805; Supplier Part Number 1: 541-280KCTR-ND; Tolerance: ±1%
R3 = CMP-1013-00017-1: Manufacturer: Vishay Dale; Manufacturer Part Number: CRCW080510K0FKTA; Power (Watts): 0.125W, 1/8W; Temperature Coefficient: ±100ppm/°C; Category: Resistors; Component Kind: Standard; ComponentLink1Description: http://www.vishay.com/docs/20008/dcrcw.pdf; ComponentLink1URL: http://www.vishay.com/docs/20008/dcrcw.pdf; Composition: Thick Film; Description: RES SMD 10K OHM 1% 1/8W 0805; Failure Rate: -; Features: Automotive AEC-Q200; Height - Seated (Max): 0.020" (0.50mm); Library Name: Altium Content Vault; Operating Temperature: -55°C ~ 155°C; Package / Case: 0805 (2012 Metric); Packaging: Digi-Reel®; Part Status: Active; Pricing 1: 1=0.14, 10=0.129, 100=0.0496, 1000=0.02183, 2500=0.01885 (USD); RoHS: RoHS non-compliant; Size / Dimension: 0.079" L x 0.049" W (2.00mm x 1.25mm); Stock 1: 5348; Supplier 1: Digi-Key; Supplier Device Package: 0805; Supplier Part Number 1: 541-3016-6-ND; Tolerance: ±1%
R4 = CMP-1013-00017-1: Manufacturer: Yageo; Manufacturer Part Number: RC0805FR-0710KL; Power (Watts): 0.125W, 1/8W; Temperature Coefficient: ±100ppm/°C; Category: Resistors; Component Kind: Standard; ComponentLink1Description: http://www.yageo.com/documents/recent/PYu-RC_Group_51_RoHS_L_7.pdf; ComponentLink1URL: http://www.yageo.com/documents/recent/PYu-RC_Group_51_RoHS_L_7.pdf; Composition: Thick Film; Description: RES SMD 10K OHM 1% 1/8W 0805; Failure Rate: -; Features: Moisture Resistant; Height - Seated (Max): 0.024" (0.60mm); Library Name: Altium Content Vault; Operating Temperature: -55°C ~ 155°C; Package / Case: 0805 (2012 Metric); Packaging: Cut Tape (CT); Part Status: Active; Pricing 1: 1=0.1, 10=0.022, 100=0.0088, 1000=0.00396, 2500=0.00344 (USD); RoHS: RoHS Compliant; Size / Dimension: 0.079" L x 0.049" W (2.00mm x 1.25mm); Stock 1: 13336570; Supplier 1: Digi-Key; Supplier Device Package: 0805; Supplier Part Number 1: 311-10.0KCRCT-ND; Tolerance: ±1%
R5 = CMP-1013-00133-1: 300K 0.125W 5% 0805 (2012 Metric)  SMD; Manufacturer: Vishay Dale; Manufacturer Part Number: CRCW0805300RFKEA; Power (Watts): 0.125W, 1/8W; Resistance (Ohms): 300; Temperature Coefficient: ±100ppm/°C; Tolerance: ±1%; Category: Resistors; Component Kind: Standard; ComponentLink1Description: http://www.vishay.com/docs/20035/dcrcwe3.pdf; ComponentLink1URL: http://www.vishay.com/docs/20035/dcrcwe3.pdf; Composition: Thick Film; Failure Rate: -; Features: Automotive AEC-Q200; Height - Seated (Max): 0.020" (0.50mm); Library Name: Altium Content Vault; Operating Temperature: -55°C ~ 155°C; Package / Case: 0805 (2012 Metric); Packaging: Cut Tape (CT); Part Status: Active; Pricing 1: 1=0.1, 10=0.044, 100=0.0178, 1000=0.00802, 2500=0.00696 (USD); RoHS: RoHS Compliant; Size / Dimension: 0.079" L x 0.049" W (2.00mm x 1.25mm); Stock 1: 79765; Supplier 1: Digi-Key; Supplier Device Package: 0805; Supplier Part Number 1: 541-300CCT-ND
R6 = CMP-1013-00017-1: Manufacturer: Vishay Dale; Manufacturer Part Number: CRCW08054K70FKEA; Power (Watts): 0.125W, 1/8W; Temperature Coefficient: ±100ppm/°C; Category: Resistors; Component Kind: Standard; ComponentLink1Description: http://www.vishay.com/docs/20035/dcrcwe3.pdf; ComponentLink1URL: http://www.vishay.com/docs/20035/dcrcwe3.pdf; Composition: Thick Film; Description: RES SMD 4.7K OHM 1% 1/8W 0805; Failure Rate: -; Features: Automotive AEC-Q200; Height - Seated (Max): 0.020" (0.50mm); Library Name: Altium Content Vault; Operating Temperature: -55°C ~ 155°C; Package / Case: 0805 (2012 Metric); Packaging: Digi-Reel®; Part Status: Active; Pricing 1: 1=0.1, 10=0.044, 100=0.0178, 1000=0.00802, 2500=0.00696 (USD); RoHS: RoHS Compliant; Size / Dimension: 0.079" L x 0.049" W (2.00mm x 1.25mm); Stock 1: 0; Supplier 1: Digi-Key; Supplier Device Package: 0805; Supplier Part Number 1: 541-4.70KCDKR-ND; Tolerance: ±1%
R7 = CMP-1013-00017-1: Manufacturer: Vishay; Manufacturer Part Number: CRCW0805100KFKEA; Power Rating: 125mW; Temperature Coefficient: 100ppm/°C; Voltage Rating: 150V; Case/Package: 0805; Case Code (Imperial): 0805; Case Code (Metric): 2012; Component Kind: Standard; ComponentLink1Description: https://datasheet.ciiva.com/11809/0900766b80d98b26-11809057.pdf; ComponentLink1URL: https://datasheet.ciiva.com/11809/0900766b80d98b26-11809057.pdf; ComponentLink2Description: https://datasheet.ciiva.com/7777/1697337-7777349.pdf; ComponentLink2URL: https://datasheet.ciiva.com/7777/1697337-7777349.pdf; ComponentLink3Description: https://datasheet.ciiva.com/3258/dcrcwe3-3258006.pdf; ComponentLink3URL: https://datasheet.ciiva.com/3258/dcrcwe3-3258006.pdf; ComponentLink4Description: https://datasheet.ciiva.com/16754/70201119-16754822.pdf; ComponentLink4URL: https://datasheet.ciiva.com/16754/70201119-16754822.pdf; ComponentLink5Description: https://datasheet.ciiva.com/8337/dcrcwe3-8337738.pdf; ComponentLink5URL: https://datasheet.ciiva.com/8337/dcrcwe3-8337738.pdf; ComponentLink6Description: https://datasheet.ciiva.com/16341/dcrcwe3-16341530.pdf; ComponentLink6URL: https://datasheet.ciiva.com/16341/dcrcwe3-16341530.pdf; ComponentLink7Description: https://datasheet.ciiva.com/16852/dcrcwe3-16852810.pdf; ComponentLink7URL: https://datasheet.ciiva.com/16852/dcrcwe3-16852810.pdf; ComponentLink8Description: https://datasheet.ciiva.com/16720/70201119-16720891.pdf; ComponentLink8URL: https://datasheet.ciiva.com/16720/70201119-16720891.pdf; ComponentLink9Description: https://datasheet.ciiva.com/7777/1720486-7777565.pdf; ComponentLink9URL: https://datasheet.ciiva.com/7777/1720486-7777565.pdf; Composition: Thick Film; Contact Plating: Tin; Depth: 1.25mm; Description: RES SMD 100K OHM 1% 1/8W 0805; Height: 0.45mm; Lead Free: Lead Free; Length: 2mm; Library Name: Altium Content Vault; Max Operating Temperature: 155°C; Military Standard: Not; Min Operating Temperature: -55°C; Mount: Surface Mount; Number of Pins: 2; Package Quantity: 5000; Packaging: Tape and Reel; Pricing 1: 1=0.1, 10=0.049, 25=0.042, 50=0.035, 100=0.028 (USD); Radiation Hardening: No; REACH SVHC: Unknown; Stock 1: 1317; Supplier 1: Avnet; Supplier Part Number 1: CRCW0805100KFKEA/BKN; Termination: SMD/SMT; Tolerance: 1%; Voltage Rating (DC): 150V; Weight: 0.000194oz; Width: 1.25mm
RL1 = CMP-1503-00270-1: PF Relays, 12 V Coil Voltage, 170 mW Nominal Operating Power, -40 to 85 degC, 5-Pin PF, Tube, Case, RoHS; Manufacturer: Panasonic; Coil Voltage: 12 V; Electrical Life: 5x10^4 (N.O.), 3x10^4 (N.C.); Max Switching Current: 6 A; Max Switching Voltage: 250 V AC; Mechanical Life(Min.operation): 5x10^6; Nominal Operating Power: 170 mW; Pb-Free: True; Component Kind: Standard; ComponentLink1Description: Manufacturer URL; ComponentLink1URL: http://www3.panasonic.biz/ac/ae/index.jsp; ComponentLink2Description: Datasheet; ComponentLink2URL: http://www3.panasonic.biz/ac/e_download/control/relay/power/catalog/mech_eng_pf.pdf?f_cd=300412; ComponentLink3Description: Package Specification; ComponentLink3URL: http://www3.panasonic.biz/ac/e_download/control/relay/power/catalog/mech_eng_pf.pdf?f_cd=300412; DatasheetVersion: 201402-T; Library Name: Altium Content Vault; Mounting Technology: Through Hole; PackageDescription: 5-Pin Through Hole Terminal Package, Body 28 x 5 mm; PackageReference: PF-5-2800x500x1500; PackageVersion: ASCTB205E, 02/2014; Packing: Tube, Case; PartNumber: APF30312; RoHS: True; Series: PF Relays
TP4 = CMP-1672-00003-4: Test Point, Black, Through Hole, RoHS, Bulk; Manufacturer: Keystone; Body Material: PBT; Gender: Female; Hole Diameter: 1.6mm; Inside Diameter: 1.78mm; Number of Ports: 1; Case/Package: 125; Colour: Black; Component Kind: Standard; ComponentLink1Description: Manufacturer URL; ComponentLink1URL: http://www.keyelco.com/; ComponentLink2Description: Datasheet; ComponentLink2URL: http://www.keyelco.com/product-pdf.cfm?p=1320; ComponentLink3Description: https://datasheet.ciiva.com/1454/1718230-1454834.pdf; ComponentLink3URL: https://datasheet.ciiva.com/1454/1718230-1454834.pdf; ComponentLink4Description: https://datasheet.ciiva.com/2917/5011-2917635.pdf; ComponentLink4URL: https://datasheet.ciiva.com/2917/5011-2917635.pdf; ComponentLink5Description: https://datasheet.ciiva.com/16351/m60-2p60-16351093.pdf; ComponentLink5URL: https://datasheet.ciiva.com/16351/m60-2p60-16351093.pdf; ComponentLink6Description: https://datasheet.ciiva.com/11744/0900766b8009b7c7-11744935.pdf; ComponentLink6URL: https://datasheet.ciiva.com/11744/0900766b8009b7c7-11744935.pdf; ComponentLink7Description: https://datasheet.ciiva.com/46412/1703983-46412138.pdf; ComponentLink7URL: https://datasheet.ciiva.com/46412/1703983-46412138.pdf; Contact Material: Bronze; Contact Plating: Silver; DatasheetVersion: Rev. F, 12/2013; Height: 8.12 mm; Housing Colour: Black; Lead Free: Lead Free; Length: 11.3mm; Library Name: Altium Content Vault; Manufacturer Part Number: 5011; Material: Bronze,Silver,Nylon; Mount: Through Hole; Mounting Technology: Through Hole; Orientation: Straight; PackageDescription: 1-Pin Through Hole Device, Dia 3.17 mm; Package Quantity: 100; PackageReference: 5011; Packaging: Bulk; Pins: 1; Pricing 1: 1=0.53, 10=0.53, 50=0.53, 100=0.371, 1000=0.165, 10000=0.106 (USD); REACH SVHC: No SVHC; RoHSCompliant: true; Stock 1: 63090; Supplier 1: Mouser; Supplier Part Number 1: 534-5011
U1 = CMP-0491-01698-1: IC REG BOOST ADJ 0.3A TSOT23-6; Manufacturer 1: Linear Technology; Manufacturer Part Number 1: LT3461AES6#TRMPBF; Category 1: Integrated Circuits (ICs); Current - Output: 300mA (Switch); Frequency - Switching: 3MHz; Function: Step-Up; Number of Outputs: 1; Output Configuration: Positive; Output Type: Adjustable; Synchronous Rectifier: No; Voltage - Input (Max): 16V; Voltage - Input (Min): 2.5V; Voltage - Output (Max): 38V; Voltage - Output (Min/Fixed): 1.255V; Component Kind: Standard; ComponentLink1Description: http://www.linear.com/docs/3469; ComponentLink1URL: http://www.linear.com/docs/3469; Description 1: IC REG BOOST ADJ 0.3A TSOT23-6; Library Name: Altium Content Vault; Mounting Type: Surface Mount; Operating Temperature: 0°C ~ 70°C (TA); Package / Case: SOT-23-6 Thin, TSOT-23-6; Packaging: Cut Tape (CT); Part Status: Active; Pricing 1: 1=3.94, 25=3.52, 100=2.4, 250=2.2 (USD); RoHS 1: RoHS Compliant; Stock 1: 1325; Supplier 1: Digi-Key; Supplier Device Package: TSOT-23-6; Supplier Part Number 1: LT3461AES6#TRMPBFCT-ND; Topology: Boost
U2 = BD90GA3WEFJ-E2: IC REG LDO 9V 0.3A 8HTSOP-J; Manufacturer: Rohm Semiconductor; Current - Output: 300mA; Regulator Topology: Positive Fixed; Voltage - Dropout (Typical): 0.6V @ 300mA; Voltage - Input: Up to 14V; Category: Integrated Circuits (ICs); Component Kind: Standard; ComponentLink1Description: http://rohmfs.rohm.com/en/products/databook/datasheet/ic/power/linear_regulator/bdxxga3wefj-e.pdf; ComponentLink1URL: http://rohmfs.rohm.com/en/products/databook/datasheet/ic/power/linear_regulator/bdxxga3wefj-e.pdf; Current - Limit (Min): -; Manufacturer Part Number: BD90GA3WEFJ-E2; Mounting Type: Surface Mount; Operating Temperature: -25°C ~ 85°C; Package / Case: 8-SOIC (0.154", 3.90mm Width) Exposed Pad; Packaging: Cut Tape (CT); Part Status: Active; Pricing 1: 1=0.69, 10=0.606, 25=0.5364, 100=0.4672, 250=0.40664, 500=0.34608, 1000=0.27686 (USD); RoHS: RoHS Compliant; Stock 1: 4781; Supplier 1: Digi-Key; Supplier Device Package: 8-HTSOP-J; Supplier Part Number 1: BD90GA3WEFJ-E2CT-ND; Voltage - Output: 9V; Library Name: ZLS_Schematic_lib.SchLib
U3 = CCG302412S: IC CONV DC-DC 15W DUAL 5V PCB; Manufacturer 1: TDK-Lambda Americas Inc.; Category 1: Power Supplies - Board Mount; Current - Output (Max): 1.5A, 1.5A; Efficiency: 85%; Number of Outputs: 2; Voltage - Input (Max): 36V; Voltage - Input (Min): 9V; Voltage - Isolation: 1.6kV (1600V); Voltage - Output 2: -5V; Applications: ITE (Commercial); Component Kind: Standard; ComponentLink1Description: http://us.tdk-lambda.com/ftp/AppNotes/pxb15w-d_appnote.pdf; ComponentLink1URL: http://us.tdk-lambda.com/ftp/AppNotes/pxb15w-d_appnote.pdf; Description 1: IC CONV DC-DC 15W DUAL 5V PCB; Features: Remote On/Off, OCP, OVP, SCP; Manufacturer Part Number 1: PXB15-24WD05/N; Mounting Type: Through Hole; Operating Temperature: -40°C ~ 85°C; Package / Case: 6-DIP Module; Packaging: Tube; Part Status: Active; Power (Watts): 15W; Pricing 1: 1=61.61, 10=59.59 (USD); RoHS 1: RoHS Compliant; Size / Dimension: 1.00" L x 1.00" W x 0.39" H (25.4mm x 25.4mm x 9.9mm); Stock 1: 28; Supplier 1: Digi-Key; Supplier Part Number 1: 285-1902-ND; Type: Isolated Module; Voltage - Output 1: 5V; Voltage - Output 3: -; Library Name: ZLS_LIB.SchLib
U4 = CMP-0476-01685-1: 500 mA, Low Noise, LDO Micropower Regulator, 1.8 to 20 V Vin, 5 V Vout, 8-pin SOIC (S8-8), -40 to 125 degC, Pb-Free; Manufacturer: Linear Technology; Discrete Pass Element: no; Dropout Voltage(V): 0.3; Iout(mA): 500; Isupply(mA): 0.03; Noise(uV): 20; Number of Outputs: 1; Output Voltage: 5 V; PSRR(dB): 65; Vin Min(V): 1.8; Component Kind: Standard; ComponentLink1Description: Manufacturer URL; ComponentLink1URL: http://www.linear.com/; ComponentLink2Description: Datasheet; ComponentLink2URL: http://cds.linear.com/docs/en/datasheet/1763fg.pdf; ComponentLink3Description: Package Specification; ComponentLink3URL: http://cds.linear.com/docs/en/packaging/05081610_G_SO8.pdf; DatasheetVersion: Rev. G; Library Name: Altium Content Vault; Mounting Technology: Surface Mount; PackageDescription: 8-Pin Plastic Small Outline (Narrow .150 Inch), Body 4.9 x 3.9 mm, Pitch 1.27 mm; PackageReference: S8-8; PackageVersion: Rev. G, 03/2012; PartNumber: LT1763CS8-5#PBF; RoHS: TRUE; Shutdown Current(uA): 1; Vin Max(V): 20
U5 = CMP-1310-00373-1: Low Voltage, Rail-to-Rail Output Operational Amplifier, 1.7 to 5.5 V, -40 to 85 degC, 5-Pin SOT-553, Pb-Free, Tape and Reel; Manufacturer: On Semiconductor; VCC Max (V): 5.5; VCC Min (V): 1.7; Component Kind: Standard; ComponentLink1Description: Manufacturer URL; ComponentLink1URL: http://www.onsemi.com/; ComponentLink2Description: Datasheet; ComponentLink2URL: http://www.onsemi.cn/pub_link/Collateral/NCS2003-D.PDF; ComponentLink3Description: Package Specification; ComponentLink3URL: http://www.onsemi.com/pub_link/Collateral/463B-01.PDF; DatasheetVersion: Rev. 3; Library Name: Altium Content Vault; Mounting Technology: Surface Mount; PackageDescription: 5-Pin Small Outline Transistor, Body 1.6 x 1.2 mm, Pitch 0.5 mm; PackageReference: SOT-553-5-463B; PackageVersion: Rev. C, 03/2013; Packing: Tape and Reel; PartNumber: NCS2003XV53T2G; RoHS: TRUE
U6 = CCG302412S: DC/DC CONVERTER 12V 2.5A PCB; Manufacturer 1: TDK-Lambda Americas Inc; Power (Watts) - Manufacture Series: 30W; Category 1: Power Supplies - Board Mount; Efficiency: 89%; Number of Outputs: 1; Voltage - Input (Max): 36V; Voltage - Input (Min): 9V; Voltage - Isolation: 1.5kV (1500V); Component Kind: Standard; ComponentLink1Description: http://www.us.tdk-lambda.com/ftp/specs/ccg30.pdf; ComponentLink1URL: http://www.us.tdk-lambda.com/ftp/specs/ccg30.pdf; Current - Output (Max): 2.5A; Description 1: DC/DC CONVERTER 12V 2.5A PCB; Features: Remote On/Off, OCP; Manufacturer Part Number 1: CCG302412S; Mounting Type: Through Hole; Operating Temperature: -40°C ~ 85°C; Package / Case: 6-DIP Module (6 Leads); Packaging: Tube; Power (Watts) - Max: 30W; Pricing 1: 1=43.63, 10=42.95 (USD); RoHS 1: RoHS Compliant; Size / Dimension: 1.00" L x 1.00" W x 0.39" H (25.4mm x 25.4mm x 9.9mm); Stock 1: 30; Supplier 1: Digi-Key; Supplier Part Number 1: 285-2419-ND; Type: Isolated Module; Voltage - Output 1: 12V; Voltage - Output 2: -; Voltage - Output 3: -; Library Name: ZLS_LIB.SchLib
